# Altium schematic sheet ESP32 WiFi.SchDoc
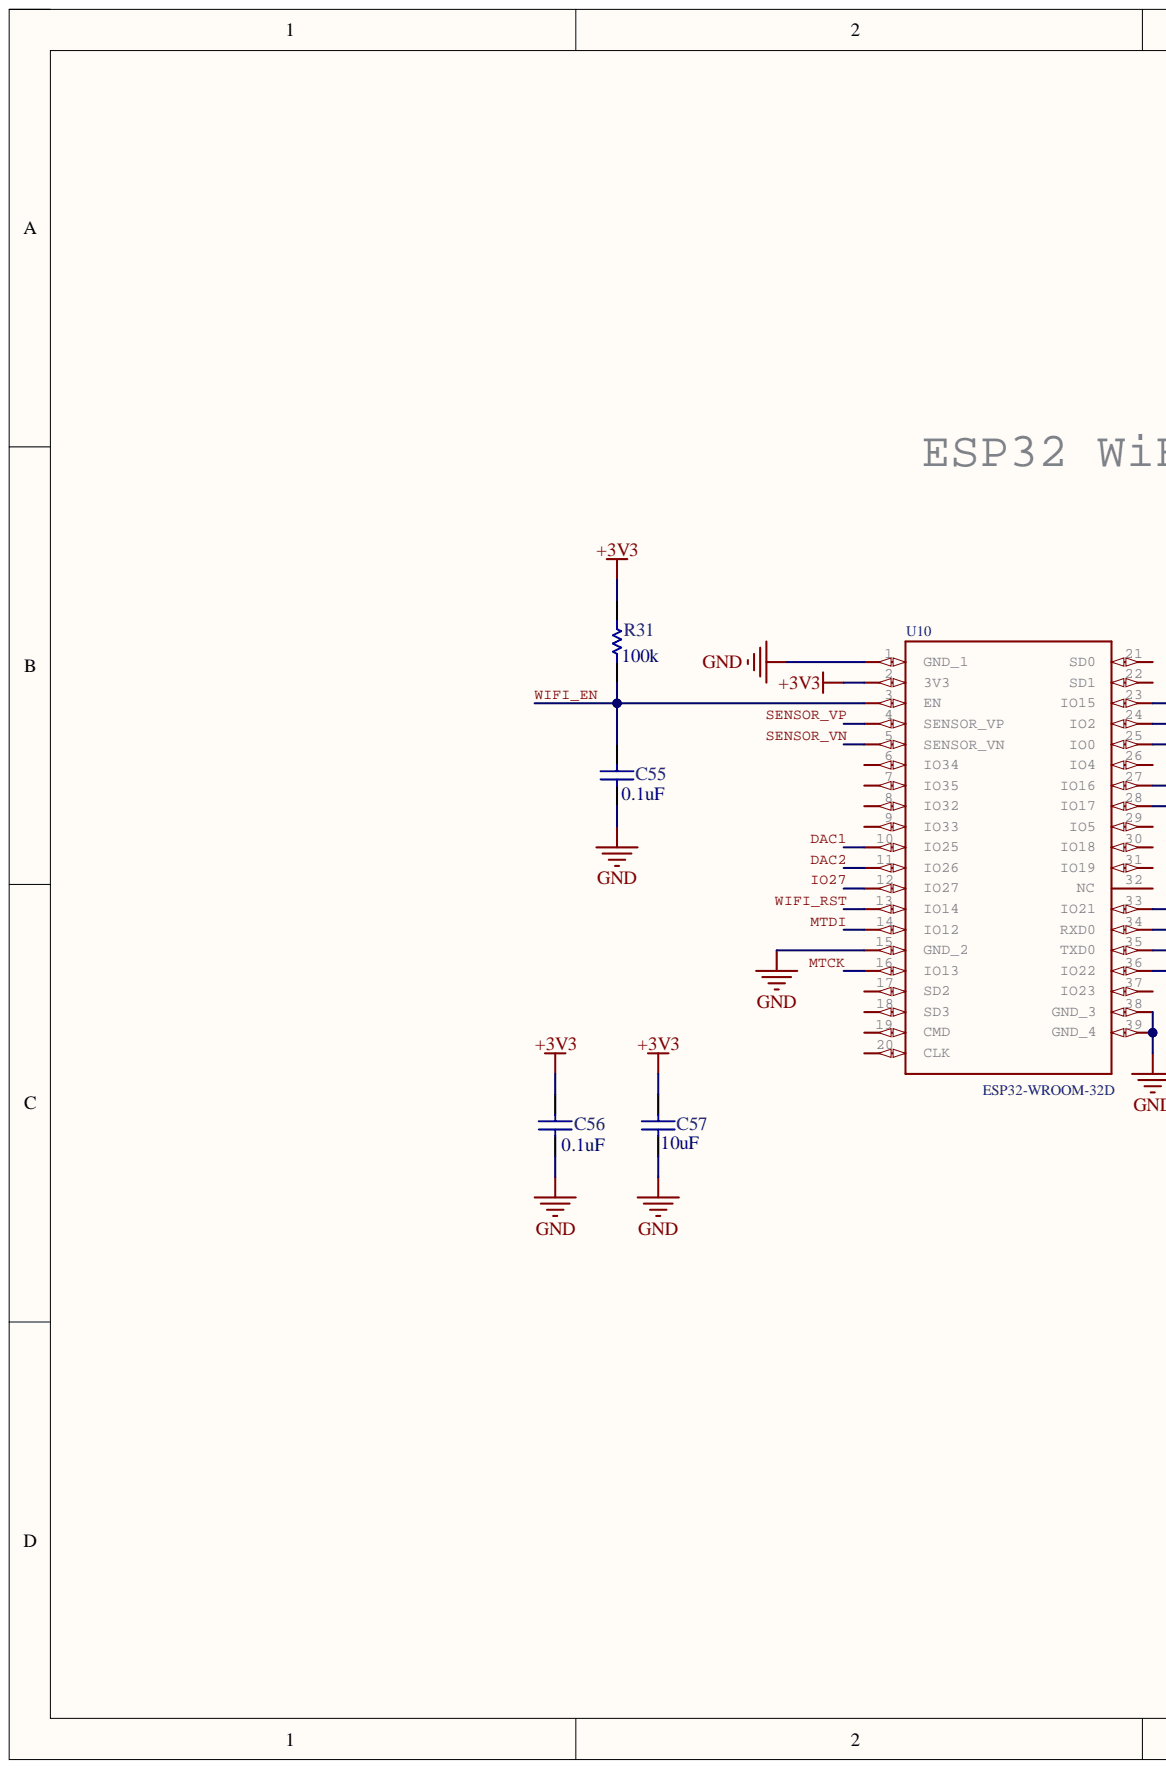
[diagram p0: ESP32 WiFi.SchDoc, left]
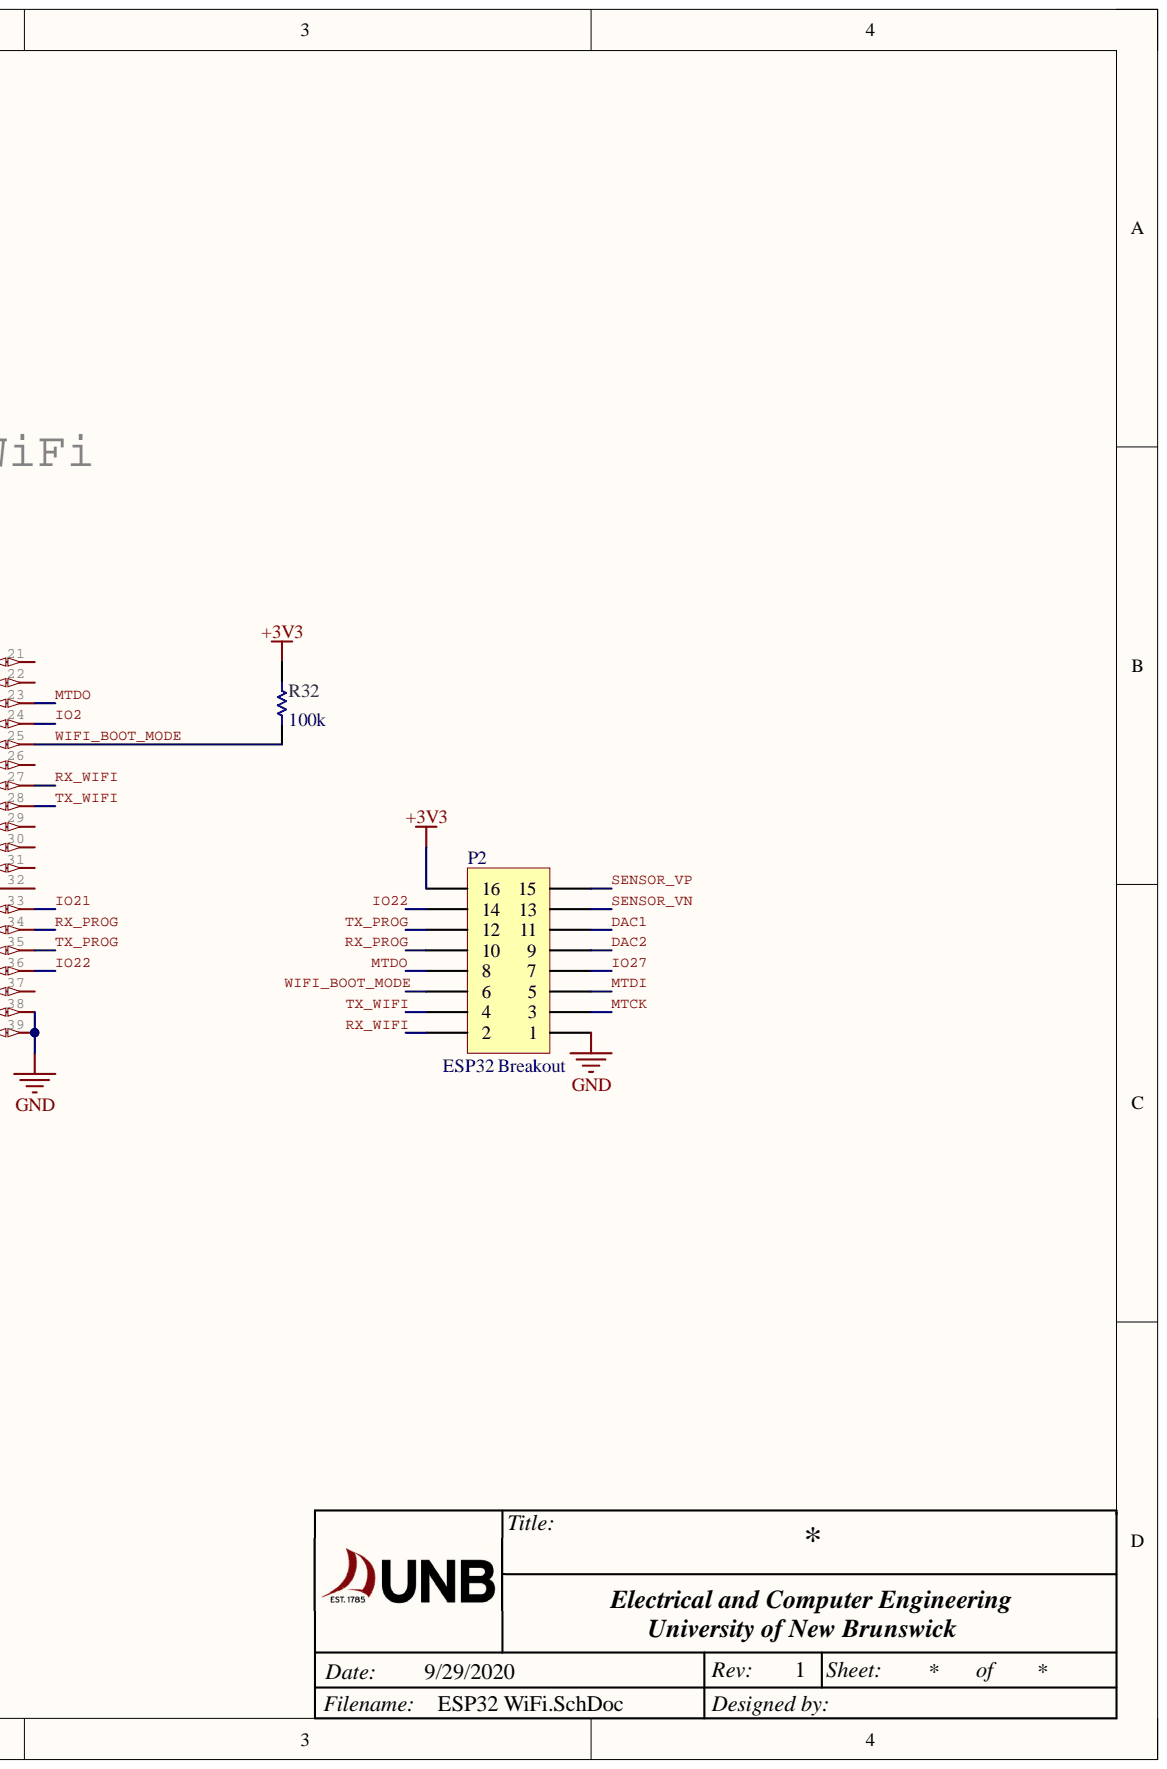
[diagram p1: ESP32 WiFi.SchDoc, right]

## Parts
C55  0.1uF  [0603 Cap]
C56  0.1uF  [0603 Cap]
C57  10uF  [0603 Cap]
P2  ESP32 Breakout  [HDR2X8]
R31  100k  [0603 Resistor]
R32  100k  [0603 Resistor]
U10  ESP32-WROOM-32D  [ESP32WROOM32D]

## Nets
+3V3: C56.2 C57.2 P2.16 R31.1 R32.1 U10.2(3V3)
GND: C55.1 C56.1 C57.1 P2.1 U10.1(GND_1) U10.15(GND_2) U10.38(GND_3) U10.39(GND_4)
DAC1: P2.11 U10.10(IO25)
DAC2: P2.9 U10.11(IO26)
IO2: U10.24(IO2)
IO21: U10.33(IO21)
IO22: P2.14 U10.36(IO22)
IO27: P2.7 U10.12(IO27)
MTCK: P2.3 U10.16(IO13)
MTDI: P2.5 U10.14(IO12)
MTDO: P2.8 U10.23(IO15)
RX_PROG: P2.10 U10.34(RXD0)
RX_WIFI: P2.2 U10.27(IO16)
SENSOR_VN: P2.13 U10.5(SENSOR_VN)
SENSOR_VP: P2.15 U10.4(SENSOR_VP)
TX_PROG: P2.12 U10.35(TXD0)
TX_WIFI: P2.4 U10.28(IO17)
WIFI_BOOT_MODE: P2.6 R32.2 U10.25(IO0)
WIFI_EN: C55.2 R31.2 U10.3(EN)
WIFI_RST: U10.13(IO14)

Not connected: U10 6(IO34) 7(IO35) 8(IO32) 9(IO33) 17(SD2) 18(SD3) 19(CMD) 20(CLK) 21(SD0) 22(SD1) 26(IO4) 29(IO5) 30(IO18) 31(IO19) 32(NC) 37(IO23)

## Library data of the parts
C55 C56 = Cap Semi: Cap Ceramic 0.1uF 50VDC X7R 10% SMD 0603 Paper T/R; Manufacturer: Samsung; Manufacturer Part Number: CL10B104KB8NNNC; Capacitance: 100nF; Voltage: 50V; Case Code (Imperial): 0603; Case Code (Metric): 1608; Case/Package: 0603; Category: Ceramic Capacitors; Component Kind: Standard; ComponentLink1Description: http://www.avx.com/docs/Catalogs/cx7r.pdf; ComponentLink1URL: http://www.avx.com/docs/Catalogs/cx7r.pdf; ComponentLink2Description: https://datasheet.ciiva.com/25803/cl10b104kb8nnnc-25803393.pdf?src-supplier=Digi-Key; ComponentLink2URL: https://datasheet.ciiva.com/25803/cl10b104kb8nnnc-25803393.pdf?src-supplier=Digi-Key; ComponentLink3Description: https://datasheet.ciiva.com/26838/getdatasheetpartid-89097-26838411.pdf?src-supplier=Verical; ComponentLink3URL: https://datasheet.ciiva.com/26838/getdatasheetpartid-89097-26838411.pdf?src-supplier=Verical; ComponentLink4Description: https://datasheet.ciiva.com/29198/456651-da-01-en-vs-kondensator-0605-29198839.pdf?src-supplier=Conrad; ComponentLink4URL: https://datasheet.ciiva.com/29198/456651-da-01-en-vs-kondensator-0605-29198839.pdf?src-supplier=Conrad; Contact Plating: Tin; Depth: 0.8mm; Dielectric: X7R; Height: 0.8mm; Lead Free: Lead Free; Length: 1.6mm; Library Name: CAN_Interface_Library.SCHLIB; Material: Ceramic; Max Operating Temperature: 125°C; Min Operating Temperature: -55°C; Mount: Surface Mount; Package Quantity: 1; Packaging: Tape and Reel; Radiation Hardening: No; RoHS: Yes; Signal Integrity: Cap Semi; Simulation: CAP; Stock 1: 17879275; Supplier 1: Digi-Key; Supplier Part Number 1: 1276-1000-6-ND; Thickness: 0.035inch; Tolerance: 10%; Value: 0.1uF; Voltage Rating: 50V; Voltage Rating (DC): 50V; Width: 0.031inch
C57 = Cap Semi: Cap Ceramic 10uF 6.3V X5R 20% Pad SMD 0603 85°C T/R; Manufacturer: Samsung; Manufacturer Part Number: CL10A106MQ8NNNC; Capacitance: 10uF; Case Code (Imperial): 0603; Case Code (Metric): 1608; Case/Package: 0603; Category: Ceramic Capacitors; Component Kind: Standard; ComponentLink1Description: http://www.avx.com/docs/Catalogs/cx7r.pdf; ComponentLink1URL: http://www.avx.com/docs/Catalogs/cx7r.pdf; ComponentLink2Description: https://datasheet.ciiva.com/25798/cl10a106mq8nnnc-25798320.pdf?src-supplier=Digi-Key; ComponentLink2URL: https://datasheet.ciiva.com/25798/cl10a106mq8nnnc-25798320.pdf?src-supplier=Digi-Key; ComponentLink3Description: https://datasheet.ciiva.com/26838/getdatasheetpartid-357557-26838347.pdf?src-supplier=Verical; ComponentLink3URL: https://datasheet.ciiva.com/26838/getdatasheetpartid-357557-26838347.pdf?src-supplier=Verical; ComponentLink4Description: https://datasheet.ciiva.com/16437/cl05b104kq5nnnc-16437327.pdf?src-supplier=Future+Electronics; ComponentLink4URL: https://datasheet.ciiva.com/16437/cl05b104kq5nnnc-16437327.pdf?src-supplier=Future+Electronics; Contact Plating: Tin; Depth: 0.8mm; Dielectric: X5R; Height: 0.8mm; Length: 1.6mm; Library Name: CAN_Interface_Library.SCHLIB; Max Operating Temperature: 85°C; Min Operating Temperature: -55°C; Mount: Surface Mount; Package Quantity: 1; Packaging: Tape and Reel; Radiation Hardening: No; RoHS: Yes; Signal Integrity: Cap Semi; Simulation: CAP; Stock 1: 1321; Supplier 1: Digi-Key; Supplier Part Number 1: 1276-1119-1-ND; Thickness: 0.035inch; Tolerance: 20%; Value: 10uF; Voltage: 6.3V; Voltage Rating: 6.3V; Width: 0.031inch
P2 = Header 8X2: CONN HDR 16POS 0.1 TIN PCB; Manufacturer: Sullins; Manufacturer Part Number: PPTC082LFBN-RC; Connector Type: Header,Socket; Number of Positions: 16; Pitch: 0.1inch; Category: Connectors; Color: Black; Component Kind: Standard; ComponentLink1Description: http://www.sullinscorp.com/catalogs/101_Page80-81_HEADER_.100_2.54mm_FEMALE.pdf?src-supplier=Future+Electronics; ComponentLink1URL: http://www.sullinscorp.com/catalogs/101_Page80-81_HEADER_.100_2.54mm_FEMALE.pdf?src-supplier=Future+Electronics; ComponentLink2Description: https://drawings-pdf.s3.amazonaws.com/10492.pdf?src-supplier=Digi-Key; ComponentLink2URL: https://drawings-pdf.s3.amazonaws.com/10492.pdf?src-supplier=Digi-Key; Contact Material: Bronze; Contact Plating: Tin; Height: 8.5mm; Housing Material: Plastic; Lead Free: Lead Free; Length: 20.8mm; Library Name: Miscellaneous Connectors.IntLib; Mount: Through Hole; Number of Rows: 2; Package Quantity: 1000; Packaging: Bulk; RoHS: Yes; Row Spacing: 0.1inch; Signal Integrity: Connector; Stock 1: 3856; Supplier 1: Digi-Key; Supplier Part Number 1: S7076-ND; Termination: Solder; Width: 5mm
R31 R32 = Res Semi: RES 100K OHM 1% 1/10W 0603; Manufacturer: Stackpole Electronics; Manufacturer Part Number: RMCF0603FT100K; Max Power Dissipation: 100mW; Resistance: 100kR; Temperature Coefficient: 100ppm/°C; Working Voltage: 75V; Case Code (Imperial): 0603; Case Code (Metric): 1608; Case/Package: 0603; Category: Chip SMD Resistors; Component Kind: Standard; ComponentLink1Description: http://www.rohm.com/web/global/datasheet/ESR01MZPF/esr-e; ComponentLink1URL: http://www.rohm.com/web/global/datasheet/ESR01MZPF/esr-e; ComponentLink2Description: https://datasheet.ciiva.com/15193/getdatasheetpartid-362597-15193437.pdf?src-supplier=Verical; ComponentLink2URL: https://datasheet.ciiva.com/15193/getdatasheetpartid-362597-15193437.pdf?src-supplier=Verical; Composition: Thick Film; Contact Plating: Tin,Matte; Depth: 0.8mm; Features: Automotive AEC-Q200; Height: 0.022inch; Lead Free: Lead Free; Length: 1.55mm; Max Operating Temperature: 155°C; Military Standard: Not; Min Operating Temperature: -55°C; Mount: Surface Mount; Number of Terminations: 2; Packaging: Tape and Reel; Power Rating: 100mW; Radiation Hardening: No; RoHS: Yes; Signal Integrity: Res Semi; Simulation: RES; Stock 1: 2666095; Supplier 1: Digi-Key; Supplier Part Number 1: RMCF0603FT100KDKR-ND; Tolerance: 1%; Value: 100k; Width: 0.031inch; Library Name: BrillianBit.SCHLIB
U10 = ESP32-WROOM-32D: Wi-Fi/BT BLE Module ESP32-D0WD, SPI Flash, UART, P; Manufacturer: Espressif Systems; Data Rate: 150Mbps; Frequency: 2.5GHz; Interface: I2C,SPI,GPIO,PWM,SDIO,UART; Memory Size: 536KB; Sensitivity: -98dBm; Case/Package: SMD/SMT; Category: RF Receivers, Transceivers; Component Kind: Standard; ComponentLink1Description: https://www.espressif.com/sites/default/files/documentation/esp32-wroom-32d_esp32-wroom-32u_datasheet_en.pdf?src-supplier=Digi-Key; ComponentLink1URL: https://www.espressif.com/sites/default/files/documentation/esp32-wroom-32d_esp32-wroom-32u_datasheet_en.pdf?src-supplier=Digi-Key; Manufacturer Part Number: ESP32-WROOM-32D; Max Operating Temperature: 85°C; Min Operating Temperature: -40°C; Mount: Surface Mount; Packaging: Cut Tape; RoHS: No; Stock 1: 10609; Supplier 1: Digi-Key; Supplier Part Number 1: 1904-1023-1-ND; Library Name: BrilliantLabsEagleLibrary.IntLib
